# Altium schematic sheet Power.SchDoc
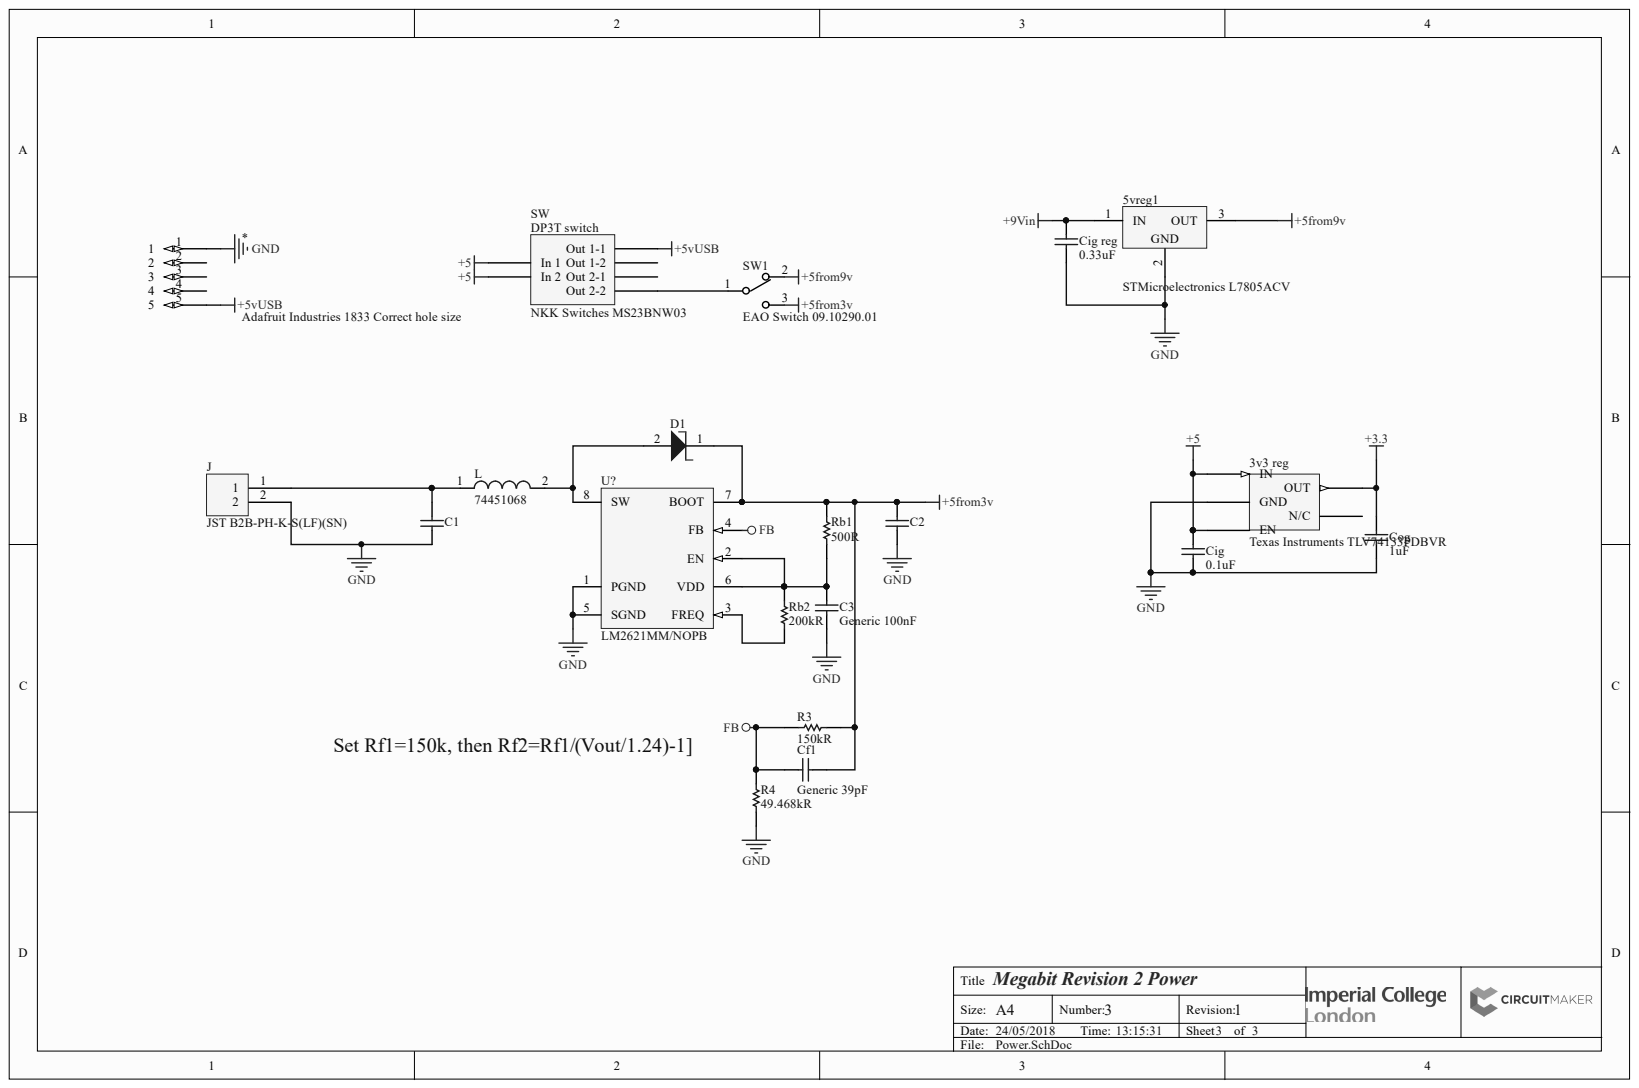
[diagram p0: Power.SchDoc]

## Parts
3v3_reg  Texas Instruments TLV74133PDBVR  Manufacturer Part Number: TLV74133PDBVR  [PCB-e30blpvsozc7nnftiis2-1]
5vreg1  STMicroelectronics L7805ACV  Manufacturer Part Number: L7805ACV  [PCB-rumztmkd2xxusznmwboq-1]
*  Adafruit Industries 1833 Correct hole size  [PCB-lrx1ysvqy1j2hi3n37c6-1]
C1  Vishay 595D226X06R3B2T 22uf  [PCB-awioszezn6dp9j1rjqrs-1]  (value not drawn)
C2  Vishay 595D686X0010C2T 68uf  [PCB-d73fplpdo6xzmazju0mb-1]  (value not drawn)
C3  Generic 100nF  [CAPC3225X270X50NL20T25]
Cf1  Generic 39pF  [CAPC3225X270X50NL20T25]
Cig  0.1uF  [Vishay K104K15X7RF5TL2]
Cig_reg  0.33uF  [Vishay K104K15X7RF5TL2]
Cog  1uF  [Vishay K104K15X7RF5TL2]
D1  Diode  [PCB-z7pk77sri5opvcrttliy-1]  (value not drawn)
J  JST B2B-PH-K-S(LF)(SN)  Manufacturer Part Number: B2B-PH-K-S(LF)(SN)  [PCB-gpdi66yn1jko56crxrq7-1]
L  74451068  [WE-PD3-S]
R3  150kR  [PCB-3613466-1]
R4  49.468kR  [PCB-3613466-1]
Rb1  500R  [PCB-3613466-1]
Rb2  200kR  [PCB-3613466-1]
SW  NKK Switches MS23BNW03  [PCB-eozfiwzr1uk89188ni00-1]
SW1  EAO Switch 09.10290.01  [PCB-1akl86m7w5ikau1ha4dm-1]
U?  LM2621MM/NOPB  [MUA08A_M]

## Nets
+3.3: 3v3_reg.4(OUT) Cog.2
+5: 3v3_reg.1(IN) 3v3_reg.3(EN) Cig.2 SW.2(In_1) SW.5(In_2)
+5FROM3V: C2.2 Cf1.1 D1.1 R3.1 Rb1.2 SW1.3 U?.7(BOOT)
+5FROM9V: 5vreg1.3 SW1.2
+5VUSB: *.5 SW.1(Out_1-1)
+9VIN: 5vreg1.1 Cig_reg.2
FB: Cf1.2 R3.2 R4.1 U?.4(FB)
GND: 3v3_reg.2(GND) 5vreg1.2 *.1 C1.1 C2.1 C3.1 Cig.1 Cig_reg.1 Cog.1 J.2 R4.2 U?.1(PGND) U?.5(SGND)
NetC1_2: C1.2 J.1 L.1
NetC3_2: C3.2 Rb1.1 Rb2.2 U?.2(EN) U?.6(VDD)
NetD1_2: D1.2 L.2 U?.8(SW)
NetRb2_1: Rb2.1 U?.3(FREQ)
NetSW1_1: SW.6(Out_2-2) SW1.1

Not connected: 3v3_reg 5(N/C); * 2 3 4; SW 3(Out_1-2) 4(Out_2-1)

## Library data of the parts
3v3_reg = CMP-ad66616159c672a1-4: 150mA Low-Dropout (LDO) Regulator With Foldback Current Limit for Portable Devices 5-SOT-23 -40 to 125; Dropout Voltage: 230 mV; Number of Regulated Outputs: 1; Output Capacitor Type: Ceramic; Quiescent Current: 50.0 µA; Case/Package: SOT-23; Component Kind: Standard; Library Name: FavoritesLibrary; Lifecycle Status: Pre-Release; Number of Pins: 5; OctopartID: ad66616159c672a1; Octopart URL: https://octopart.com/tlv74133pdbvr-texas+instruments-84791750; Operating Temperature: -40.0 °C to 125 °C; RoHS: Compliant
5vreg1 = CMP-1170713-16: L7805 Series 1.5 A 5 V Three Terminal Voltage Regulator - TO-220; Dropout Voltage: 2.00 V; Input Voltage (DC): 20.0 V (max); Lead-Free Status: Lead Free; Number of Outputs: 1; Output Current: 1.00 A, 1.50 A; Output Voltage: 5.00 V (max); Case/Package: TO-220; Component Kind: Standard; Library Name: FavoritesLibrary; Lifecycle Status: Active; Mounting Style: Through Hole; Number of Pins: 3; OctopartID: b0837beb020f6f44; Octopart URL: https://octopart.com/l7805acv-stmicroelectronics-40015850; Operating Temperature: 0.00 °C to 150 °C; Packaging: Tube; REACH SVHC Compliance: No SVHC; RoHS: Compliant
* = CMP-bfb17591a6f36076-2: Standoff; Threaded Female 1/4 Hex; 6-32 Thread; Length .875; Brass/Zinc; Manufacturer Part Number: 1833; Lead-Free Status: Lead Free; Component Kind: Standard; Gender: Female; Library Name: FavoritesLibrary; Material: Brass; OctopartID: bfb17591a6f36076; Octopart URL: http://octopart.com/1833-adafruit+industries-53099556; RoHS: Compliant; Size-Diameter: 6.35 mm; Size-Length: 22.2 mm; Size-Width: 6.35 mm
C1 = CMP-0470cff745dfdbb7-1: Cap Tant Solid 22uF 6.3V B CASE 20% (4 X 2.8 X 1.9mm) SMD 0.57 Ohm 125°C T/R; Component Kind: Standard; Library Name: CommunityVault; Manufacturer Part Number: 595D226X06R3B2T; Mounting Style: Surface Mount; OctopartID: 0470cff745dfdbb7; Octopart URL: https://octopart.com/595d226x06r3b2t-vishay-42820809; Packaging: Reel
C2 = CMP-af556406ccca7092-1: Capacitor; Tantalum; Cap 68 uF; Tol 20%; Vol-Rtg 10 VDC; SMT; ESR 0.24 Ohms; Case C; Capacitance: 68.0 µF; Equivalent Series Resistance (ESR): 240 m?; Lead-Free Status: Lead Free; Voltage Rating (DC): 10.0 V; Capacitance Tolerance: ±20%; Component Kind: Standard; Dielectric Material: Tantalum; Library Name: CommunityVault; Manufacturer Part Number: 595D686X0010C2T; Mounting Style: Surface Mount, PCB; Number of Pins: 2; OctopartID: af556406ccca7092; Octopart URL: https://octopart.com/595d686x0010c2t-vishay-39405305; Operating Temperature: -55.0 °C to 85.0 °C; Packaging: Reel; RoHS: Compliant
C3 Cf1 = CMP-7582759-2: Cap Ceramic 22uF 6.3V X7R 10% SMD 1210 125C Plastic T/R; Capacitance: 2.2e-05 F; Lead-Free Status: R; Capacitance Tolerance: ±10%; Case/Package: 1210; Component Kind: Standard; Dielectric Characteristic: X7R; Dielectric Material: Ceramic; Library Name: CommunityVault; Mounting Style: Surface Mount; OctopartID: 3960f1ef97dce6a7; Octopart Url: https://octopart.com/c1210c226k9rac7800-kemet-25529306; RoHS: Compliant; Voltage Rating (DC): 6.3 V
Cig Cig_reg Cog = CMP-124240-16: K Series 100nF 50 V ±10 % Tolerance X7R Radial Multilayer Ceramic Capacitor; Manufacturer Part Number: K104K15X7RF5TL2; Capacitance Tolerance: ±10%; Lead-Free Status: Lead Free; Lead Length: 30mm; Voltage Rating (DC): 50 V; Capacitance: 100 nF; Component Kind: Standard; Dielectric Characteristic: X7R; Dielectric Material: Ceramic; Library Name: CommunityVault; Mounting Style: Through Hole; Number of Pins: 2; OctopartID: e7292e20c87538ee; Octopart URL: http://octopart.com/k104k15x7rf5tl2-vishay+bccomponents-199226; Packaging: Cut Tape (CT), Tape & Reel (TR); RoHS: Compliant; Size-Height: 5.6mm; Size-Lead Diameter: 0.5mm; Size-Thickness: 2.6mm; Size-Width: 4.0mm
D1 = CMP-816651-5: Schottky Power Rectifier, 2-Pin SMB, Pb-Free, Tape and Reel; Current Rating: 1.0 A; Lead-Free Status: Lead Free; Component Kind: Standard; Halogen Free Status: Halogen Free; Library Name: CommunityVault; Number of Pins: 2; OctopartID: 7211bb1b496aadd6; REACH SVHC Compliance: No SVHC
J = CMP-642947-7: PH Series 2 Position 2 mm Pitch Through Hole Top Entry Shrouded Header; Contact Resistance: 10.0 mΩ; Current Rating: 2.00 A; Gender: Male; Insulation Resistance: 1.00 MΩ; Lead-Free Status: Lead Free; Number of Contacts: 2; Pin Pitch: 2.00 mm; Voltage Rating (AC): 100 V; Component Kind: Standard; Contact Material: Brass; Contact Plating: Tin; Housing Color: Natural; Housing Material: Nylon; Library Name: CommunityVault; Mounting Style: Through Hole, Vertical; Number of Positions: 2; Number of Rows: 1; OctopartID: a21c6b154b5e7ddb; Octopart URL: http://octopart.com/b2b-ph-k-s%28lf%29%28sn%29-jst-248868; Packaging: Bulk; REACH SVHC Compliance: No SVHC; RoHS: Compliant; Size-Height: 6.00 mm; Size-Length: 5.90 mm; Size-Width: 4.50 mm
L = CMP-2520931-2: SMD Shielded Power Inductor WE-PD3, L = 6.80 µH; Inductance: 6.8e-06 H; Resistance: 0.055 Ω; Resonant Frequency: 50000000.0 Hz; Component Kind: Standard; Library Name: CommunityVault; Number of Pins: 2; OctopartID: 2a29e3ab1e4ff5ee; Octopart Url: https://octopart.com/74451068-w%C3%BCrth+elektronik-8917248; Packaging: Cut Tape (CT); REACH SVHC Compliance: No SVHC; RoHS: Compliant; Self Resonant Frequency: 50000000.0 Hz
R3 R4 Rb1 Rb2 = CMP-b15c796618a36e9b-3: Resistor 0.25W; Component Kind: Standard; Library Name: CommunityVault; OctopartID: b15c796618a36e9b
SW = CMP-e82c21266ecb37eb-4: dp3t on off on switch; Component Kind: Standard; Library Name: FavoritesLibrary
SW1 = CMP-a30d6b12014b7cca-5: SLIDE SWITCH, SPDT, HORIZ; Series: 1K2; Switch Function Type: SPDT, R/A; Contact Configuration: SPDT; Voltage, Contac...; Contact Resistance: 22.0 mΩ; Insulation Resistance: 10.0 GΩ; Component Kind: Standard; Contacts Type: SPDT; Library Name: CommunityVault; Manufacturer Part Number: 09.10290.01; Mounting Style: PCB; OctopartID: a30d6b12014b7cca; Octopart URL: http://octopart.com/09.10290.01-eao+switch-18188064; Orientation: Right Angle; RoHS: Compliant
U? = CMP-0069-01749-4: Low Input Voltage, Step-Up DC-DC, 8-pin MSOP, Pb-Free; Frequency: 2000000.0 Hz; Lead-Free Status: Lead Free; Number of Outputs: 1; Output Current: 1.0 A; Output Voltage: 14.0 V; Quiescent Current: 8e-05 A; Case/Package: MSOP; Component Kind: Standard; Library Name: CommunityVault; Lifecycle Status: Active; Mounting Style: Surface Mount; Number of Pins: 8; Number of Regulated Outputs: 1; OctopartID: 8eac46dc360e516b; Octopart Url: https://octopart.com/lm2621mm%2Fnopb-texas+instruments-24812996; Packaging: Cut Tape (CT); REACH SVHC Compliance: No SVHC; RoHS: Compliant
